# Altium schematic sheet SPI.SchDoc(SPI)
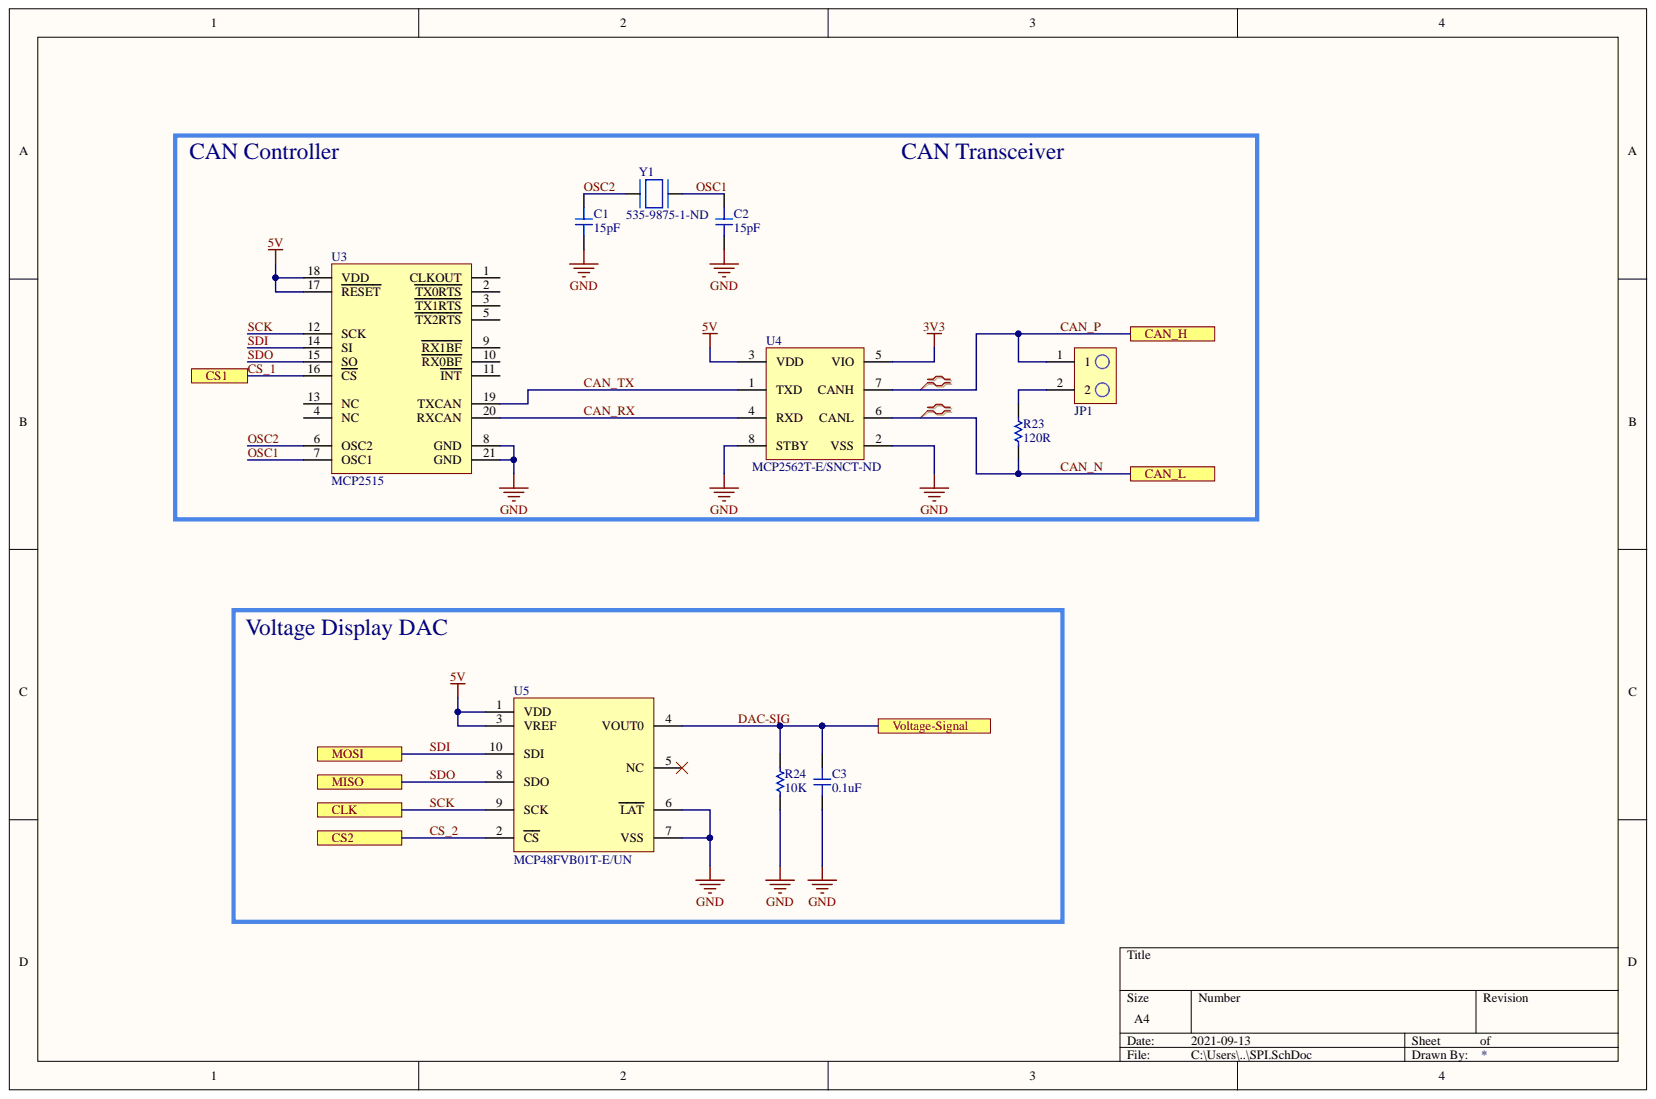
[diagram p0: SPI.SchDoc]

## Parts
C1  15pF  [CAP-0603]
C2  15pF  [CAP-0603]
C3  0.1uF  [CAP-0805]
JP1  732-5315-ND  [2PIN-JP]  (value not drawn)
R23  120R  [RES-0603]
R24  10K  [RES-0603]
U3  MCP2515  [QFN20-EP]
U4  MCP2562T-E/SNCT-ND  [8-SOIC w/o THRM]
U5  MCP48FVB01T-E/UN  [10MSOP]
Y1  535-9875-1-ND  [CRYSTAL-ABLS2]

## Ports
CAN_H, CAN_L, CLK, CS1, CS2, MISO, MOSI, Voltage-Signal

## Nets
3V3: U4.5(VIO)
5V: U3.17(RESET) U3.18(VDD) U4.3(VDD) U5.1(VDD) U5.3(VREF)
GND: C1.1 C2.1 C3.1 R24.1 U3.8(GND) U3.21(GND) U4.2(VSS) U4.8(STBY) U5.6(LAT) U5.7(VSS)
CAN_N: R23.1 U4.6(CANL) ; port CAN_L
CAN_P: JP1.1 U4.7(CANH) ; port CAN_H
CAN_RX: U3.20(RXCAN) U4.4(RXD)
CAN_TX: U3.19(TXCAN) U4.1(TXD)
CS_1: U3.16(CS) ; port CS1
CS_2: U5.2(CS) ; port CS2
DAC-SIG: C3.2 R24.2 U5.4(VOUT0) ; port Voltage-Signal
OSC1: C2.2 U3.7(OSC1) Y1.2
OSC2: C1.2 U3.6(OSC2) Y1.1
SCK: U3.12(SCK) U5.9(SCK) ; port CLK
SDI: U3.14(SI) U5.10(SDI) ; port MOSI
SDO: U3.15(SO) U5.8(SDO) ; port MISO
NetJP1_2: JP1.2 R23.2

Not connected: U3 1(CLKOUT) 2(TX0RTS) 3(TX1RTS) 4(NC) 5(TX2RTS) 9(RX1BF) 10(RX0BF) 11(INT) 13(NC); U5 5(NC)

## Library data of the parts
C1 C2 = 0603-15pF-100V: CAP CER 15PF 100V C0G/NP0 0603; Manufacturer Part Number: GRM1885C2A150JA01D; Manufacturer: Murata; Tolerance: 5%; Material: Ceramic; Dielectric: C0G; Width: 0.8mm; Voltage Rating (DC): 100V; Voltage Rating: 100V; Voltage: 100V; Thickness: 0.035inch; Termination: SMD/SMT; Supplier Part Number 1: 490-1331-6-ND; Supplier 1: Digi-Key; Stock 1: 216098; Radiation Hardening: No; Pricing 1: 1=0.12, 10=0.088, 100=0.0413, 500=0.02896, 1000=0.02397 (USD); Packaging: Tape and Reel; Package Quantity: 1; Mount: Surface Mount; Min Operating Temperature: -55°C; Max Operating Temperature: 125°C; Library Name: Capacitors.SchLib; Length: 1.6mm; Lead Free: Lead Free; Height: 0.8mm; Depth: 0.8mm; ComponentLink6URL: https://produktinfo.conrad.com/datenblaetter/450000-474999/451247-da-01-en-VS_KONDENSATOR_0603.pdf?src-supplier=Conrad; ComponentLink6Description: https://produktinfo.conrad.com/datenblaetter/450000-474999/451247-da-01-en-VS_KONDENSATOR_0603.pdf?src-supplier=Conrad; ComponentLink5URL: http://search.murata.co.jp/Ceramy/image/img/A01X/partnumbering_e_01.pdf?src-supplier=Digi-Key; ComponentLink5Description: http://search.murata.co.jp/Ceramy/image/img/A01X/partnumbering_e_01.pdf?src-supplier=Digi-Key; ComponentLink4URL: https://www.murata.com/~/media/webrenewal/support/library/catalog/products/capacitor/mlcc/c02e.ashx?la=en-us&src-supplier=Digi-Key; ComponentLink4Description: https://www.murata.com/~/media/webrenewal/support/library/catalog/products/capacitor/mlcc/c02e.ashx?la=en-us&src-supplier=Digi-Key; ComponentLink3URL: http://www.mouser.com/ds/2/281/c02e-2905.pdf?src-supplier=Mouser; ComponentLink3Description: http://www.mouser.com/ds/2/281/c02e-2905.pdf?src-supplier=Mouser; ComponentLink2URL: http://psearch.murata.com/capacitor/product/GRM1885C2A150JA01%23.pdf?src-supplier=Avnet; ComponentLink2Description: http://psearch.murata.com/capacitor/product/GRM1885C2A150JA01%23.pdf?src-supplier=Avnet; ComponentLink1URL: http://components.arrow.com/part/dataview/40422412S9717204N2606?src-supplier=Arrow; ComponentLink1Description: http://components.arrow.com/part/dataview/40422412S9717204N2606?src-supplier=Arrow; Component Kind: Standard; Case Code (Metric): 1608; Case Code (Imperial): 0603; Case/Package: 0603; Capacitance: 15pF
C3 = C0805C104Z5VACTU: CAP CER 0.1UF 50V Y5V 0805; Manufacturer: KEMET; Tolerance: 80%; Dissipation Factor: 5%; Dielectric Material: Ceramic; Capacitance: 100nF; Width: 0.049inch; Voltage Rating (DC): 50V; Voltage Rating: 50V; Voltage: 50V; Thickness: 0.039inch; Termination: SMD/SMT; Supplier Part Number 1: 399-1177-6-ND; Supplier 1: Digi-Key; Stock 1: 929243; REACH SVHC: No SVHC; Radiation Hardening: No; Pricing 1: 1=0.1, 10=0.057, 100=0.0254, 500=0.01818, 1000=0.01428 (USD); Packaging: Tape and Reel; Package Quantity: 1; Number of Pins: 2; Mount: Surface Mount,PCB; Min Operating Temperature: -30°C; Max Operating Temperature: 85°C; Manufacturer Part Number: C0805C104Z5VACTU; Length: 2mm; Lead Pitch: 0.75mm; Lead Free: Lead Free; Height: 0.9mm; Dielectric: Y5V; Depth: 1.25mm; ComponentLink4URL: http://www.farnell.com/datasheets/2123124.pdf?src-supplier=Element14; ComponentLink4Description: http://www.farnell.com/datasheets/2123124.pdf?src-supplier=Element14; ComponentLink3URL: https://www.verical.com/server-webapp/html/getDatasheet?partID=321176&src-supplier=Verical; ComponentLink3Description: https://www.verical.com/server-webapp/html/getDatasheet?partID=321176&src-supplier=Verical; ComponentLink2URL: https://www.verical.com/server-webapp/html/getDatasheet?partID=37101&src-supplier=Verical; ComponentLink2Description: https://www.verical.com/server-webapp/html/getDatasheet?partID=37101&src-supplier=Verical; ComponentLink1URL: http://www.alliedelec.com/m/d/9bfe7773041ffe9d406e68bc7b377fdd.pdf?src-supplier=Allied+Electronics; ComponentLink1Description: http://www.alliedelec.com/m/d/9bfe7773041ffe9d406e68bc7b377fdd.pdf?src-supplier=Allied+Electronics; Component Kind: Standard; Case Code (Metric): 2012; Case Code (Imperial): 0805; Case/Package: 0805; Library Name: WorkshopSlib.SchLib
JP1 = 2PIN-TEST: CONN HEADER VERT 2POS 2.54MM; Library Name: Connectors.SchLib; Component Kind: Standard
R23 = 0603-120R: RES SMD 120 OHM 1% 1/10W 0603; Manufacturer Part Number: RC0603FR-07120RL; Manufacturer: Yageo; Voltage Rating (DC): 50V; Temperature Coefficient: 100ppm/°C; Power Rating: 100mW; Width: 0.031inch; Voltage Rating: 50V; Tolerance: 1%; Termination: SMD/SMT; Supplier Part Number 1: 311-120HRDKR-ND; Supplier 1: Digi-Key; Stock 1: 1047274; Resistance: 120R; REACH SVHC: No SVHC; Pricing 1: 1=0.1, 10=0.023, 100=0.0094, 1000=0.00421, 2500=0.00366 (USD); Packaging: Tape and Reel; Package Quantity: 1; Number of Terminations: 2; Number of Pins: 2; Min Operating Temperature: -55°C; Military Standard: Not; Max Power Dissipation: 100mW; Max Operating Temperature: 155°C; Library Name: Resistors.SchLib; Length: 1.6mm; Lead Free: Lead Free; Height: 0.022inch; Features: Moisture Resistant; Depth: 0.8mm; Composition: Thick Film; ComponentLink4URL: http://www.farnell.com/datasheets/443971.pdf?src-supplier=Element14; ComponentLink4Description: http://www.farnell.com/datasheets/443971.pdf?src-supplier=Element14; ComponentLink3URL: http://www.farnell.com/datasheets/1868787.pdf?src-supplier=Element14; ComponentLink3Description: http://www.farnell.com/datasheets/1868787.pdf?src-supplier=Element14; ComponentLink2URL: http://www.farnell.com/datasheets/2167052.pdf?src-supplier=Element14; ComponentLink2Description: http://www.farnell.com/datasheets/2167052.pdf?src-supplier=Element14; ComponentLink1URL: http://www.yageo.com/documents/recent/PYu-RC_Group_51_RoHS_L_10.pdf?src-supplier=Digi-Key; ComponentLink1Description: http://www.yageo.com/documents/recent/PYu-RC_Group_51_RoHS_L_10.pdf?src-supplier=Digi-Key; Component Kind: Standard; Case Code (Metric): 1608; Case Code (Imperial): 0603; Case/Package: 0603
R24 = RC0603JR-0710KL: RES SMD 10K OHM 5% 1/10W 0603; Manufacturer: Yageo; Voltage Rating (DC): 50V; Temperature Coefficient: 100ppm/°C; Resistance: 10kR; Power Rating: 100mW; Width: 0.031inch; Voltage Rating: 50V; Tolerance: 5%; Termination: SMD/SMT; Supplier Part Number 1: 311-10KGRDKR-ND; Supplier 1: Digi-Key; Stock 1: 3006558; REACH SVHC: Unknown; Radiation Hardening: No; Pricing 1: 1=0.1, 10=0.019, 100=0.0077, 1000=0.00347, 2500=0.00301 (USD); Packaging: Tape and Reel; Number of Terminations: 2; Number of Pins: 2; Min Operating Temperature: -55°C; Military Standard: Not; Max Power Dissipation: 100mW; Max Operating Temperature: 155°C; Manufacturer Part Number: RC0603JR-0710KL; Length: 0.063inch; Lead Free: Lead Free; Height - Seated (Max): 0.022inch; Features: Moisture Resistant; Depth: 0.8mm; Contact Plating: Tin,Matte; Composition: Thick Film; ComponentLink1URL: http://www.yageo.com/documents/recent/PYu-RC_Group_51_RoHS_L_10.pdf?src-supplier=Digi-Key; ComponentLink1Description: http://www.yageo.com/documents/recent/PYu-RC_Group_51_RoHS_L_10.pdf?src-supplier=Digi-Key; Component Kind: Standard; Case Code (Metric): 1608; Case Code (Imperial): 0603; Case/Package: 0603; Library Name: WorkshopSlib.SchLib
U3 = MCP2515-E/ML: CAN CONTROLLER, 1TX/RX, QFN-20; IC Interface Type:SPI; No. of TX Buffers:3; No. of RX Buffers:2; Supply Voltage Min:2.7V; Supply Voltage Max:5.5V; Interface Case Style:QFN; No. of Pins:20; Operating Temperature Min:-40°C; Operating ;RoHS Compliant: Yes; Manufacturer: Microchip; Operating Supply Current: 10mA; Number of Transmit Buffers: 3; Number of Transceivers: 1; Number of Receive Buffers: 2; Min Supply Voltage: 2.7V; Max Supply Voltage: 5.5V; Interface: SPI; Width: 4mm; Supplier Part Number 1: MCP2515-E/ML-ND; Supplier 1: Digi-Key; Stock 1: 1168; RoHS: Yes; REACH SVHC: No SVHC; Pricing 1: 1=2.58000, 25=2.34000 (USD); Packaging: Rail/Tube; Package Quantity: 91; Number of Pins: 20; Mount: Surface Mount; Min Operating Temperature: -40°C; Max Supply Current: 10mA; Max Operating Temperature: 125°C; Manufacturer Part Number: MCP2515-E/ML; Length: 4mm; Height: 0.95mm; ComponentLink1URL: https://datasheet.ciiva.com/46406/1669372-46406021.pdf?src-supplier=Element14; ComponentLink1Description: https://datasheet.ciiva.com/46406/1669372-46406021.pdf?src-supplier=Element14; Component Kind: Standard; Category: Integrated Circuits (ICs); Case/Package: QFN; Library Name: WorkshopSlib.SchLib
U4 = CAN TRAN-MCP2562T-E/SN: IC TRANSCEIVER HALF 1/1 8SOIC; Manufacturer: Microchip; Turn-On Delay Time: 125ns; Receiver Hysteresis: 200mV; Number of Transceivers: 1; Nominal Supply Current: 85uA; Min Supply Voltage: 4.5V; Max Supply Voltage: 5.5V; Data Rate: 1Mbps; Supplier Part Number 1: MCP2562T-E/SNDKR-ND; Supplier 1: Digi-Key; Stock 1: 0; Radiation Hardening: No; Propagation Delay: 125ns; Pricing 1: 1=0.9, 25=0.75, 100=0.68 (USD); Packaging: Tape and Reel; Package Quantity: 3300; Number of Pins: 8; Mount: Surface Mount; Min Operating Temperature: -40°C; Max Operating Temperature: 125°C; Manufacturer Part Number: MCP2562T-E/SN; Library Name: ICs.SchLib; Lead Free: Lead Free; ComponentLink2URL: http://ww1.microchip.com/downloads/en/DeviceDoc/25167B.pdf?src-supplier=Avnet; ComponentLink2Description: http://ww1.microchip.com/downloads/en/DeviceDoc/25167B.pdf?src-supplier=Avnet; ComponentLink1URL: http://ww1.microchip.com/downloads/en/DeviceDoc/25167B.pdf?src-supplier=Digi-Key; ComponentLink1Description: http://ww1.microchip.com/downloads/en/DeviceDoc/25167B.pdf?src-supplier=Digi-Key; Component Kind: Standard; Case/Package: SOIC
U5 = MCP48FVB01T-E/UN: IC DAC 8BIT V-OUT 10MSOP; Manufacturer: Microchip; Settling Time: 7.8us; Number of D/A Converters: 1; Number of Bits: 8; Interface: SPI; Differential Output: No; Supplier Part Number 1: MCP48FVB01T-E/UN-ND; Supplier 1: Digi-Key; Stock 1: 0; RoHS Compliant: Yes; Pricing 1: 2500=0.585 (USD); Packaging: Tape and Reel; Min Operating Temperature: -40°C; Max Operating Temperature: 125°C; Manufacturer Part Number: MCP48FVB01T-E/UN; ComponentLink1URL: http://www.microchip.com/mymicrochip/filehandler.aspx?ddocname=en584450&src-supplier=Digi-Key; ComponentLink1Description: http://www.microchip.com/mymicrochip/filehandler.aspx?ddocname=en584450&src-supplier=Digi-Key; Component Kind: Standard; Case/Package: MSOP; Library Name: WorkshopSlib.SchLib
Y1 = Crysatl-16Mhz: CRYSTAL 16.0000MHZ 18PF SMD; Manufacturer Part Number: ABLS2-16.000MHZ-D4Y-T; Manufacturer: Abracon; Tolerance: 30ppm; Series Resistance: 40R; Frequency: 16MHz; Width: 0.185inch; Termination: SMD/SMT; Supplier Part Number 1: 535-9875-6-ND; Supplier 1: Digi-Key; Stock 1: 11099; REACH SVHC: Unknown; Pricing 1: 1=0.46, 10=0.385, 50=0.3466, 100=0.308, 500=0.2926 (USD); Packaging: Tape and Reel; Package Quantity: 1000; Mount: Surface Mount; Min Operating Temperature: -40°C; Max Operating Temperature: 85°C; Load Capacitance: 18pF; Library Name: Misc.SchLib; Length: 11.05mm; Lead Free: Lead Free; Height: 0.13inch; Frequency Tolerance: 30ppm; Frequency Stability: 30ppm; ESR (Equivalent Series Resistance): 40R; Depth: 4.8mm; ComponentLink3URL: https://www.verical.com/server-webapp/html/getDatasheet?partID=719900&src-supplier=Verical; ComponentLink3Description: https://www.verical.com/server-webapp/html/getDatasheet?partID=719900&src-supplier=Verical; ComponentLink2URL: http://www.abracon.com/Resonators/ABLS2.pdf?src-supplier=Digi-Key; ComponentLink2Description: http://www.abracon.com/Resonators/ABLS2.pdf?src-supplier=Digi-Key; ComponentLink1URL: https://abracon.com/Resonators/ABLS2.pdf?src-supplier=Digi-Key; ComponentLink1Description: https://abracon.com/Resonators/ABLS2.pdf?src-supplier=Digi-Key; Component Kind: Standard
